# Altium schematic sheet 03-Front Panel SFP_1.SchDoc("03-Front Panel SFP_1")
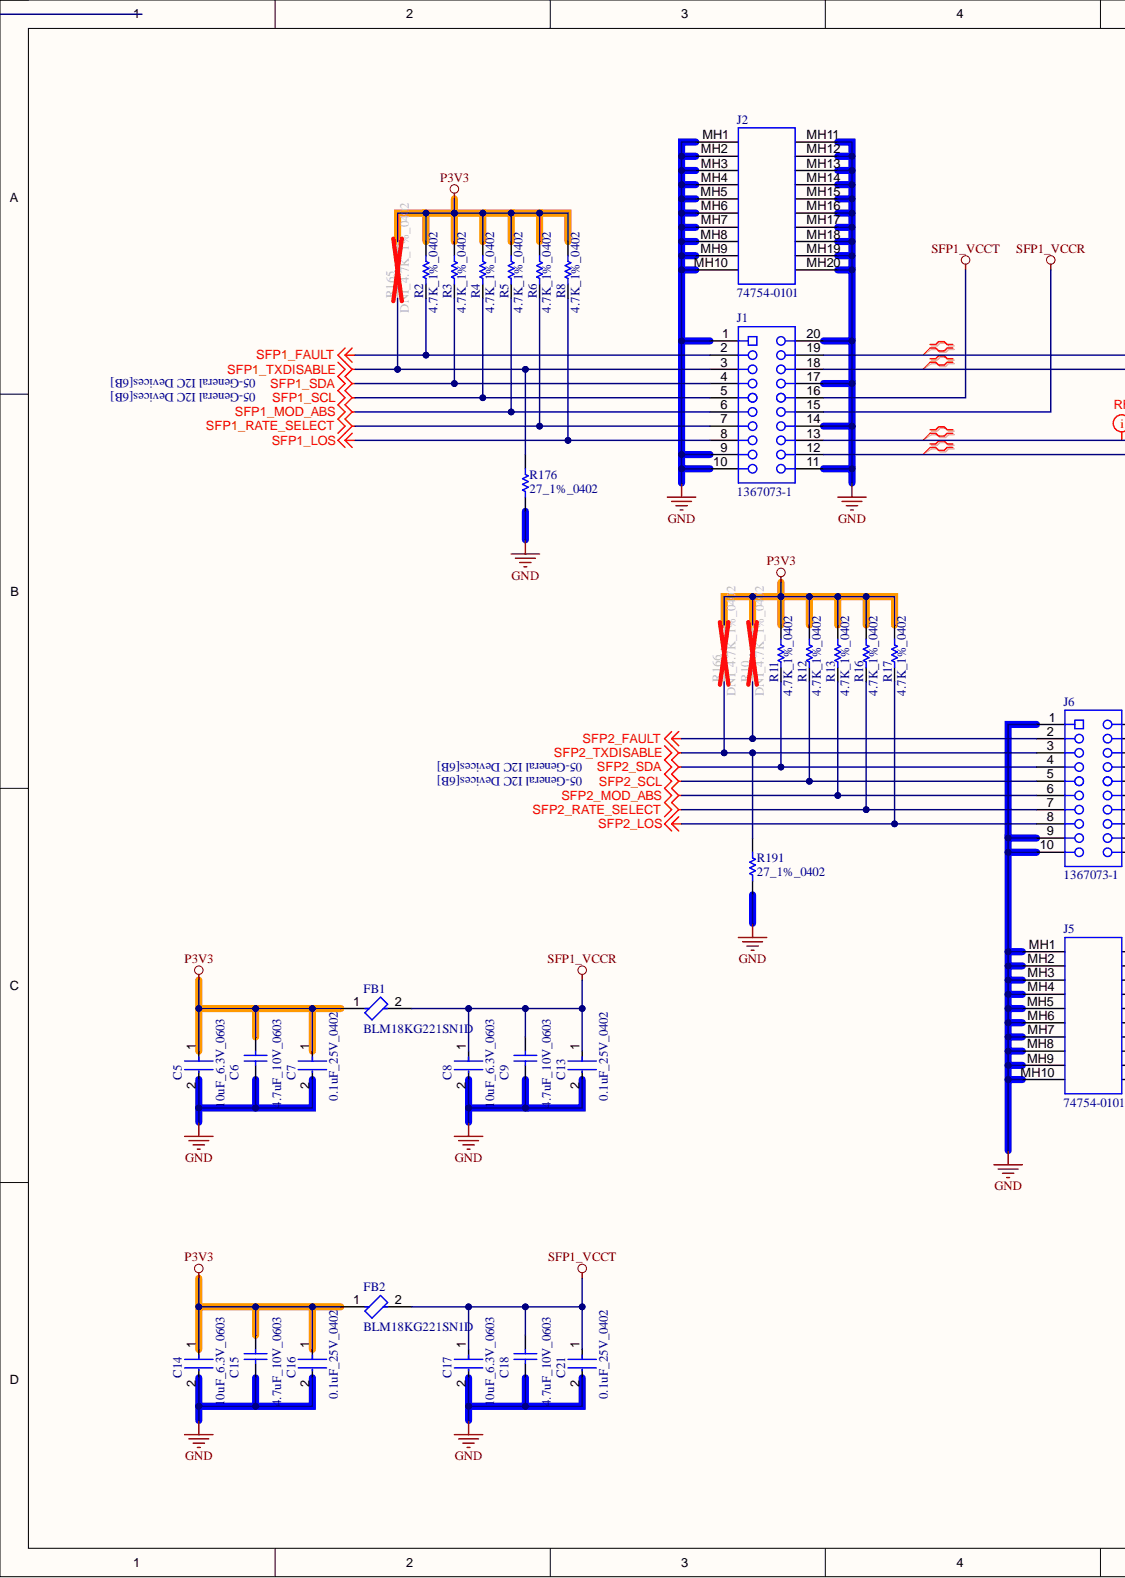
[diagram p0: 03-Front Panel SFP_1.SchDoc, left]
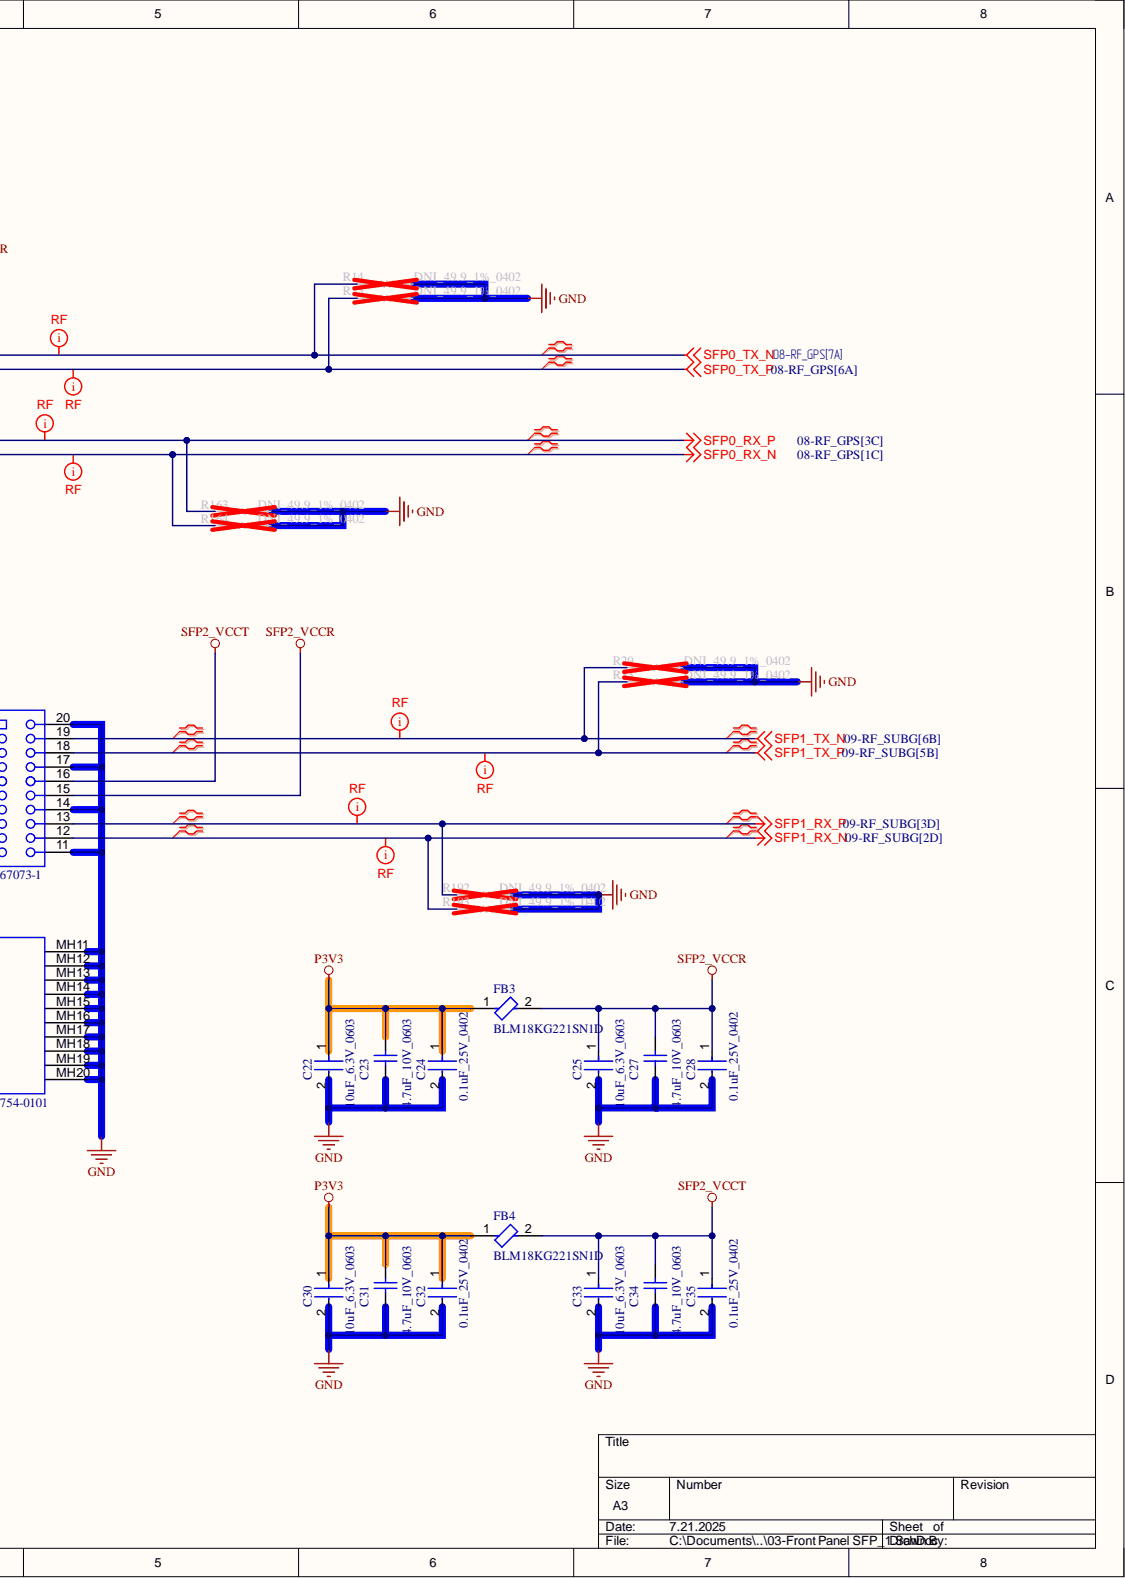
[diagram p1: 03-Front Panel SFP_1.SchDoc, right]

## Parts
C5  10uF_6.3V_0603  [FP-C0603C106M9PACTU-MFG]
C6  4.7uF_10V_0603  [CAPC1608X87X35NL15T15]
C7  0.1uF_25V_0402  [FP-0402-L_1_0_1-W_0_5_0_1-IPC_C]
C8  10uF_6.3V_0603  [FP-C0603C106M9PACTU-MFG]
C9  4.7uF_10V_0603  [CAPC1608X87X35NL15T15]
C13  0.1uF_25V_0402  [FP-0402-L_1_0_1-W_0_5_0_1-IPC_C]
C14  10uF_6.3V_0603  [FP-C0603C106M9PACTU-MFG]
C15  4.7uF_10V_0603  [CAPC1608X87X35NL15T15]
C16  0.1uF_25V_0402  [FP-0402-L_1_0_1-W_0_5_0_1-IPC_C]
C17  10uF_6.3V_0603  [FP-C0603C106M9PACTU-MFG]
C18  4.7uF_10V_0603  [CAPC1608X87X35NL15T15]
C21  0.1uF_25V_0402  [FP-0402-L_1_0_1-W_0_5_0_1-IPC_C]
C22  10uF_6.3V_0603  [FP-C0603C106M9PACTU-MFG]
C23  4.7uF_10V_0603  [CAPC1608X87X35NL15T15]
C24  0.1uF_25V_0402  [FP-0402-L_1_0_1-W_0_5_0_1-IPC_C]
C25  10uF_6.3V_0603  [FP-C0603C106M9PACTU-MFG]
C27  4.7uF_10V_0603  [CAPC1608X87X35NL15T15]
C28  0.1uF_25V_0402  [FP-0402-L_1_0_1-W_0_5_0_1-IPC_C]
C30  10uF_6.3V_0603  [FP-C0603C106M9PACTU-MFG]
C31  4.7uF_10V_0603  [CAPC1608X87X35NL15T15]
C32  0.1uF_25V_0402  [FP-0402-L_1_0_1-W_0_5_0_1-IPC_C]
C33  10uF_6.3V_0603  [FP-C0603C106M9PACTU-MFG]
C34  4.7uF_10V_0603  [CAPC1608X87X35NL15T15]
C35  0.1uF_25V_0402  [FP-0402-L_1_0_1-W_0_5_0_1-IPC_C]
FB1  BLM18KG221SN1D  [FP-BLM18-0_15-t0_8-IPC_B]
FB2  BLM18KG221SN1D  [FP-BLM18-0_15-t0_8-IPC_B]
FB3  BLM18KG221SN1D  [FP-BLM18-0_15-t0_8-IPC_B]
FB4  BLM18KG221SN1D  [FP-BLM18-0_15-t0_8-IPC_B]
J1  1367073-1  [TECO-1367073-1_V]
J2  74754-0101  [MOLX-74754-0101_V]
J5  74754-0101  [MOLX-74754-0101_V]
J6  1367073-1  [TECO-1367073-1_V]
R2  4.7K_1%_0402  [RESC1005X40X25NL10T10]
R3  4.7K_1%_0402  [RESC1005X40X25NL10T10]
R4  4.7K_1%_0402  [RESC1005X40X25NL10T10]
R5  4.7K_1%_0402  [RESC1005X40X25NL10T10]
R6  4.7K_1%_0402  [RESC1005X40X25NL10T10]
R8  4.7K_1%_0402  [RESC1005X40X25NL10T10]
R10  DNI_4.7K_1%_0402  [RESC1005X40X25NL10T10]  (no BOM)
R11  4.7K_1%_0402  [RESC1005X40X25NL10T10]
R12  4.7K_1%_0402  [RESC1005X40X25NL10T10]
R13  4.7K_1%_0402  [RESC1005X40X25NL10T10]
R14  DNI_49.9_1%_0402  [RESC1005X40X25NL10T10]  (no BOM)
R16  4.7K_1%_0402  [RESC1005X40X25NL10T10]
R17  4.7K_1%_0402  [RESC1005X40X25NL10T10]
R25  DNI_49.9_1%_0402  [RESC1005X40X25NL10T10]  (no BOM)
R29  DNI_49.9_1%_0402  [RESC1005X40X25NL10T10]  (no BOM)
R33  DNI_49.9_1%_0402  [RESC1005X40X25NL10T10]  (no BOM)
R163  DNI_49.9_1%_0402  [RESC1005X40X25NL10T10]  (no BOM)
R164  DNI_49.9_1%_0402  [RESC1005X40X25NL10T10]  (no BOM)
R165  DNI_4.7K_1%_0402  [RESC1005X40X25NL10T10]  (no BOM)
R166  DNI_4.7K_1%_0402  [RESC1005X40X25NL10T10]  (no BOM)
R176  27_1%_0402  [RESC1005X40X25NL05T05]
R191  27_1%_0402  [RESC1005X40X25NL05T05]
R192  DNI_49.9_1%_0402  [RESC1005X40X25NL10T10]  (no BOM)
R193  DNI_49.9_1%_0402  [RESC1005X40X25NL10T10]  (no BOM)

## Nets
GND: C5.2 C6.1 C7.2 C8.2 C9.1 C13.2 C14.2 C15.1 C16.2 C17.2 C18.1 C21.2 C22.2 C23.1 C24.2 C25.2 C27.1 C28.2 C30.2 C31.1 C32.2 C33.2 C34.1 C35.2 J1.1 J1.9 J1.10 J1.11 J1.14 J1.17 J1.20 J2.MH1 J2.MH2 J2.MH3 J2.MH4 J2.MH5 J2.MH6 J2.MH7 J2.MH8 J2.MH9 J2.MH10 J2.MH11 J2.MH12 J2.MH13 J2.MH14 J2.MH15 J2.MH16 J2.MH17 J2.MH18 J2.MH19 J2.MH20 J5.MH1 J5.MH2 J5.MH3 J5.MH4 J5.MH5 J5.MH6 J5.MH7 J5.MH8 J5.MH9 J5.MH10 J5.MH11 J5.MH12 J5.MH13 J5.MH14 J5.MH15 J5.MH16 J5.MH17 J5.MH18 J5.MH19 J5.MH20 J6.1 J6.9 J6.10 J6.11 J6.14 J6.17 J6.20 R14.2 R25.2 R29.2 R33.2 R163.2 R164.2 R176.1 R191.1 R192.2 R193.2
P3V3: C5.1 C6.2 C7.1 C14.1 C15.2 C16.1 C22.1 C23.2 C24.1 C30.1 C31.2 C32.1 FB1.1 FB2.1 FB3.1 FB4.1 R2.1 R3.1 R4.1 R5.1 R6.1 R8.1 R10.1 R11.1 R12.1 R13.1 R16.1 R17.1 R165.1 R166.1
SFP0_RX_N: J1.12 R164.1
SFP0_RX_P: J1.13 R163.1
SFP0_TX_N: J1.19 R14.1
SFP0_TX_P: J1.18 R25.1
SFP1_FAULT: J1.2 R2.2
SFP1_LOS: J1.8 R8.2
SFP1_MOD_ABS: J1.6 R5.2
SFP1_RATE_SELECT: J1.7 R6.2
SFP1_RX_N: J6.12 R193.1
SFP1_RX_P: J6.13 R192.1
SFP1_SCL: J1.5 R4.2
SFP1_SDA: J1.4 R3.2
SFP1_TX_N: J6.19 R29.1
SFP1_TX_P: J6.18 R33.1
SFP1_TXDISABLE: J1.3 R165.2 R176.2
SFP1_VCCR: C8.1 C9.2 C13.1 FB1.2 J1.15
SFP1_VCCT: C17.1 C18.2 C21.1 FB2.2 J1.16
SFP2_FAULT: J6.2 R10.2
SFP2_LOS: J6.8 R17.2
SFP2_MOD_ABS: J6.6 R13.2
SFP2_RATE_SELECT: J6.7 R16.2
SFP2_SCL: J6.5 R12.2
SFP2_SDA: J6.4 R11.2
SFP2_TXDISABLE: J6.3 R166.2 R191.2
SFP2_VCCR: C25.1 C27.2 C28.1 FB3.2 J6.15
SFP2_VCCT: C33.1 C34.2 C35.1 FB4.2 J6.16

## Library data of the parts
C5 C8 C14 C17 C22 C25 C30 C33 = CMP-2006-02285-3: CAP CER 10UF 6.3V X5R 0603; Manufacturer: Kemet; Manufacturer Part Number: C0603C106M9PACTU; Material: Ceramic; Temperature Coefficient: 15%; Tolerance: 20%; Automotive: No; Capacitance: 10uF; Category: Cap; Component Kind: Standard; ComponentLink2Description: Datasheet; ComponentLink2URL: http://www.kemet.com/Lists/ProductCatalog/Attachments/19/KEM_C1006_X5R_SMD.pdf; Device Class L1: Passive Components; Device Class L2: Capacitors; Device Class L3: Ceramic Capacitors; Digikey Description: CAP CER 10UF 6.3V X5R 0603; Height: 0.9mm; Ipc Land Pattern Name: CAPC16080X80; Lead Free: Yes; Library Name: Altium Content Vault; Mouser Description: In a Pack of 10, KEMET 0603 (1608M) 10µF MLCC 6.3V dc ±20% SMD C0603C106M9PACTU; Octopart: https://octopart.com/c0603c106m9pactu-kemet-8375727; Package: C0603C106M9PACTU; RoHS: TRUE; Temperature: -55°C to +85°C; Temperature Characteristic: X5R; Temperature Range High: +85°C; Temperature Range Low: -55°C; Value: 10uF; Voltage Rating: 6.3V
C6 C9 C15 C18 C23 C27 C31 C34 = CMP-2006-03238-1: Manufacturer: Kemet; Part Number: C0603C475K8PACTU; Component Kind: Standard; Library Name: Altium Content Vault
C7 C13 C16 C21 C24 C28 C32 C35 = CMP-2008-02519-2: General Purpose Ceramic Capacitor, 0402, 100nF, 10%, X7R, 15%, 25V; Capacitance: 100nF; Case Code (Imperial): 0402; Case Code (Metric): 1005; Case/Package: 0402; Component Kind: Standard; Construction: Flat; Depth: 0.5mm; Dielectric: X7R; Lead Free: Lead Free; Length: 1mm; Library Name: Altium Content Vault; Max Operating Temperature: 125°C; Min Operating Temperature: -55°C; Mount: Surface Mount; Number of Pins: 2; Package Quantity: 1; Packaging: Tape and Reel; Radiation Hardening: No; REACH SVHC: No SVHC; RoHS Compliant: Yes; Series: -; Termination: SMD/SMT; Thickness: 0.022inch; Tolerance: 10%; Voltage: 25V; Voltage Rating: 25V; Voltage Rating (DC): 25V; Width: 0.02inch
FB1-FB4 = CMP-0686-00556-7: Chip Ferrite Bead, 0603, 220Ω @ 100MHz, 0.05Ω, 25%, 2.2A; DC Resistance (DCR): 50mR; Impedance: 220R; Number of Circuits: 1; Case Code (Imperial): 0603; Case Code (Metric): 1608; Case/Package: 0603; Component Kind: Standard; Core Material: Ferrite; Current Rating: 2.2A; DC Current: 2.2A; DC Resistance-Max: 50mR; Depth: 0.8mm; Height: 0.8mm; Inductor Type: FERRITE BEAD; Lead Free: Lead Free; Length: 1.6mm; Library Name: Altium Content Vault; Material: Ferrite; Max Current Rating: 2.2A; Max DC Current: 2.2A; Max Operating Temperature: 125°C; Military Standard: Not; Min Operating Temperature: -55°C; Number of Lines: 1; Number of Pins: 2; Package Quantity: 1; Packaging: Tape and Reel; Radiation Hardening: No; REACH SVHC: No SVHC; RoHS Compliant: Yes; Series: BLM18K; Termination: SMD/SMT; Test Frequency: 100MHz; Tolerance: 25%; Weight: 7.1E-05oz; Width: 0.8mm
J1 J6 = CMP-2000-05488-1: Female Connector, Pitch 0.8 mm, 2 x 10 Position, Height 5.4 mm, -55 to 85 degC, RoHS, Tape and Reel; Manufacturer: TE Connectivity; 3D Model: 1367073-1.pdf; Associated Product: A103997CT-ND  -CONNSFPCAGESINGLEW/OPIPE  A103997TR-ND  -CONNSFPCAGESINGLEW/OPIPE  1367645-3-ND  -CONNCAGE&HEATSINKSGLPORTTIN  1367645-2-ND  -CONNCAGE&HEATSINKSGLPORTTIN  1888424-1-ND  -CONNCAGE1X2SFPENHANCEDEMI  1367643-1-ND  -CONNCAGESNGLPORTDBLSIDETIN  1489962-1-ND  -CONNSFPCAGESINGLEW/OPIPE  1367645-1-ND  -CONNCAGE&HEATSINKSGLPORTTIN  A99375-ND  -CONNSFPCAGE1X4PIN-IN-PASTE  A97412CT-ND  -CONNSFPLOWERCAGEPCB  More...; Connector Style: Receptacle; Connector Type: SFP; Dynamic Catalog: SFPConnectors; Featured Product: HighSpeedPluggableI-OSolutions; Mating Products: 516-2084-ND  -TXRXOPTICALSFF1.25GBDISCSI; Number of Positions: 20; Other Names: A97430DKR; Product Training Modules: HighSpeedPluggableI/OSolutions; Category: Connectors,Interconnects; Component Kind: Standard; Contact Finish: Gold; Contact Finish Thickness: 15µin(0.38µm); Datasheet URL: http://www.te.com/commerce/DocumentDelivery/DDEController?Action=showdoc&DocId=Customer+Drawing%7F1367073%7FK%7Fpdf%7FEnglish%7FENG_CD_1367073_K.pdf%7F1367073-1; Datasheet Version: Rev. K, 12/2012; Family: PluggableConnectors; Library Name: Altium Content Vault; Manufacturer URL: http://www.te.com/; Mounting Technology: SurfaceMount; Mounting Type: SurfaceMount,RightAngle; Package Description: Female Connector, Pitch 0.8 mm, 2 x 10 Position, Height 5.4 mm; Package Reference: 1367073-1; Packaging: Digi-Reel®; RoHS Information: 1367073-1StatementofCompliance; Standard Package: 1; Termination: Solder
J2 J5 = CMP-1704-00003-3: SFP+ Cage, Single Port, Height 10.85 mm, Tail Height 3.05 mm, -40 to 85 degC, RoHS, Tray; Component Kind: Standard; ComponentLink1Description: Manufacturer URL; ComponentLink1URL: http://www.molex.com/; ComponentLink2Description: Datasheet; ComponentLink2URL: http://www.molex.com/webdocs/datasheets/pdf/en-us/0747540101_IO_CONNECTORS.pdf; ComponentLink3Description: Package Specification; ComponentLink3URL: http://www.molex.com/pdm_docs/sd/747540101_sd.pdf; DatasheetVersion: Rev. Jul-15; Height: 10.85 mm; Length: 15.9 mm; Library Name: Altium Content Vault; Mounting Technology: Through Hole; Package Quantity: 1152; PackageDescription: SFP+ Cage, Single Port, Height 10.85 mm, Tail Height 3.05 mm; PackageVersion: Rev. J, 02/2015; Packaging: Tray; PartNumber: 74754-0101; Radiation Hardening: No; RoHSCompliant: True; Width: 49 mm
R2-R6 R8 R10-R13 R16 R17 R165 R166 = CMP-2002-05217-1: Manufacturer: Bourns; Part Number: CR0402-FX-4701GLF; Library Name: Altium Content Vault
  R2-R6 R8 R11-R13 R16 R17: Component Kind: Standard
R14 R25 R29 R33 R163 R164 R192 R193 = CMP-2002-04448-1: Manufacturer: KOA Speer; Part Number: RK73H1ETTP49R9F; Library Name: Altium Content Vault
R176 R191 = CMP-2002-08434-1: Manufacturer: Vishay Dale; Part Number: CRCW040227R0FKED; Component Kind: Standard; Library Name: Altium Content Vault
